# Altium schematic sheet Power_Synth.SchDoc(Power_Synth)
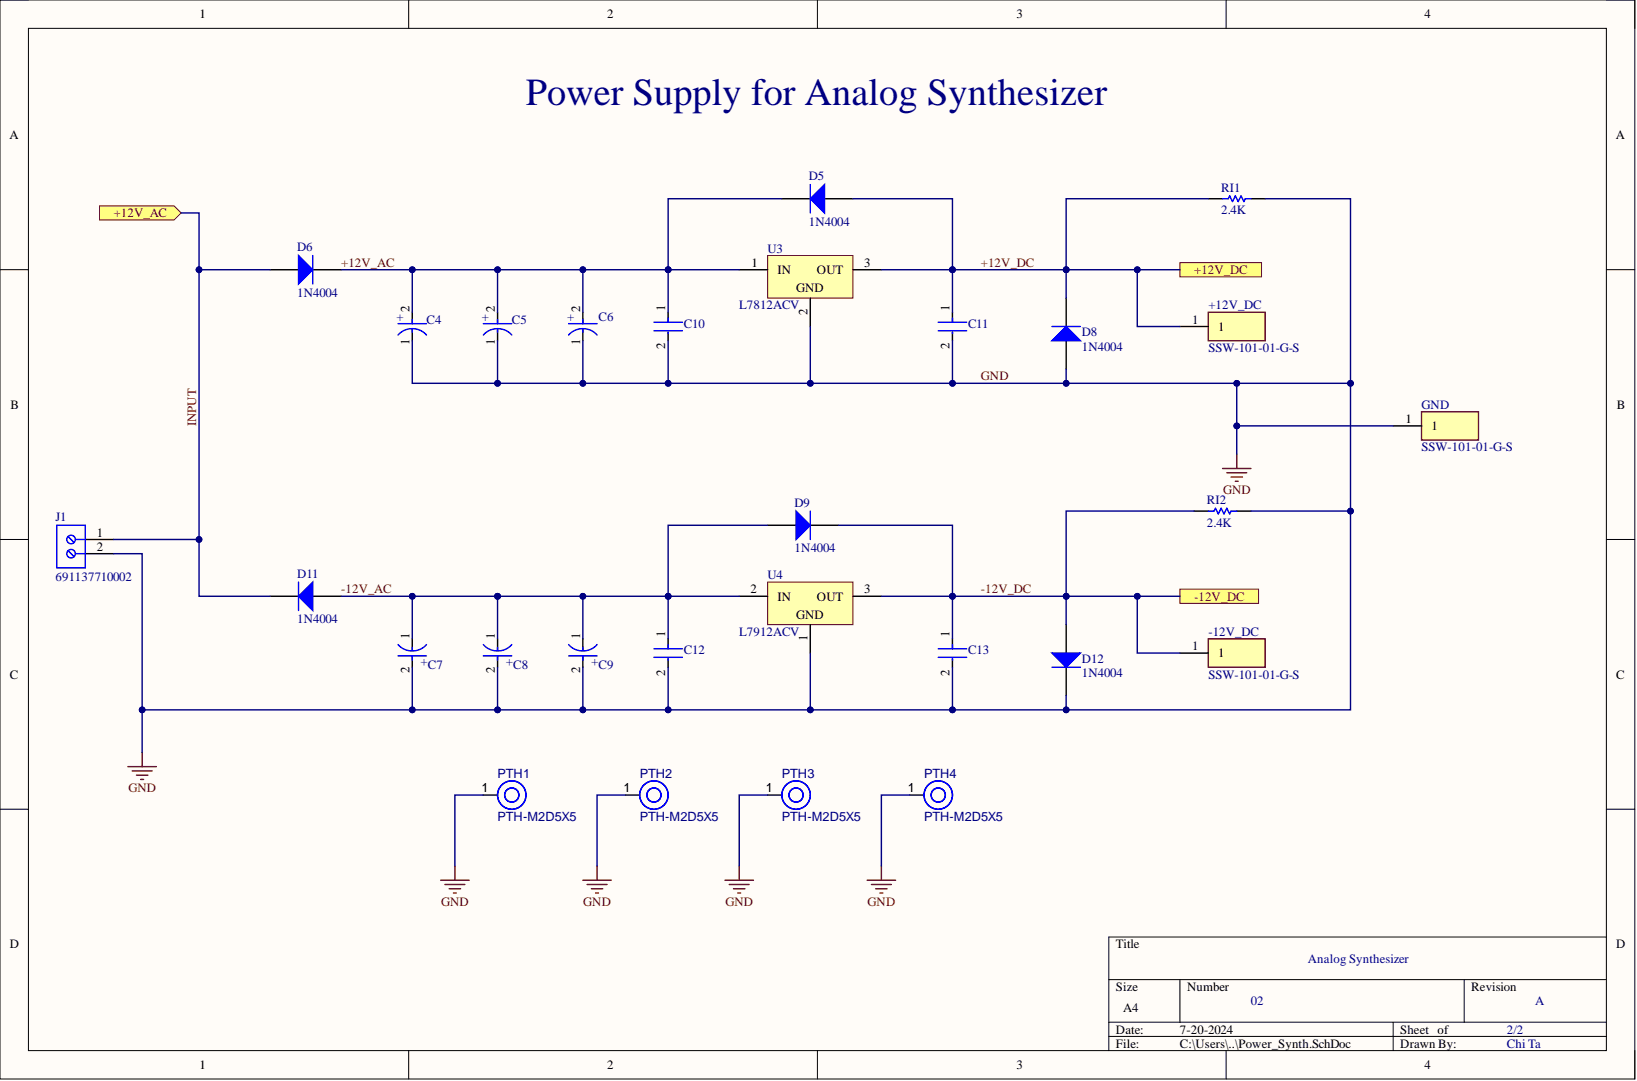
[diagram p0: Power_Synth.SchDoc]

## Parts
+12V_DC  SSW-101-01-G-S  [SSW-101-XX-YYY-S]
-12V_DC  SSW-101-01-G-S  [SSW-101-XX-YYY-S]
C4  3300uF  [FP-RAD-TH-D_18_0_5-L_35_5-MFG]  (value not drawn)
C5  3300uF  [FP-RAD-TH-D_18_0_5-L_35_5-MFG]  (value not drawn)
C6  3300uF  [FP-RAD-TH-D_18_0_5-L_35_5-MFG]  (value not drawn)
C7  3300uF  [FP-RAD-TH-D_18_0_5-L_35_5-MFG]  (value not drawn)
C8  3300uF  [FP-RAD-TH-D_18_0_5-L_35_5-MFG]  (value not drawn)
C9  3300uF  [FP-RAD-TH-D_18_0_5-L_35_5-MFG]  (value not drawn)
C10  1uF  [FP-R82DC4100DQ60J-MFG]  (value not drawn)
C11  1uF  [FP-R82DC4100DQ60J-MFG]  (value not drawn)
C12  1uF  [FP-R82DC4100DQ60J-MFG]  (value not drawn)
C13  1uF  [FP-R82DC4100DQ60J-MFG]  (value not drawn)
D5  1N4004  [FAIR-DO-41-2]
D6  1N4004  [FAIR-DO-41-2]
D8  1N4004  [FAIR-DO-41-2]
D9  1N4004  [FAIR-DO-41-2]
D11  1N4004  [FAIR-DO-41-2]
D12  1N4004  [FAIR-DO-41-2]
GND  SSW-101-01-G-S  [SSW-101-XX-YYY-S]
J1  691137710002  [691137710002]
PTH1  PTH-M2D5X5  [PTH-M2D5X5]
PTH2  PTH-M2D5X5  [PTH-M2D5X5]
PTH3  PTH-M2D5X5  [PTH-M2D5X5]
PTH4  PTH-M2D5X5  [PTH-M2D5X5]
RI1  2.4K  [RESA60-630X240]
RI2  2.4K  [RESA60-630X240]
U3  L7812ACV  [TO220]
U4  L7912ACV  [TO220]

## Ports
+12V_AC, +12V_DC, -12V_DC

## Nets
+12V_AC: C4.2 C5.2 C6.2 C10.1 D5.2 D6.2 U3.1
+12V_DC: +12V_DC.1 C11.1 D5.1 D8.2 RI1.2 U3.3 ; port +12V_DC
-12V_AC: C7.1 C8.1 C9.1 C12.1 D9.1 D11.1 U4.2
-12V_DC: -12V_DC.1 C13.1 D9.2 D12.1 RI2.2 U4.3 ; port -12V_DC
GND: C4.1 C5.1 C6.1 C7.2 C8.2 C9.2 C10.2 C11.2 C12.2 C13.2 D8.1 D12.2 GND.1 J1.2 PTH1.1 PTH2.1 PTH3.1 PTH4.1 RI1.1 RI2.1 U3.2 U4.1
INPUT: D6.1 D11.2 J1.1 ; port +12V_AC

## Library data of the parts
+12V_DC -12V_DC GND = SSW-101-01-G-S: Connector; Manufacturer_Name: SAMTEC; Arrow Part Number: SSW-101-01-G-S; Arrow Price/Stock: https://www.arrow.com/en/products/ssw-101-01-g-s/samtec; Component Kind: Standard; Datasheet Link: http://suddendocs.samtec.com/prints/ssw-1xx-xx-xxx-x-xx-xxx-xx-mkt.pdf; Height: 8.51mm; Manufacturer_Part_Number: SSW-101-01-G-S; Mouser Part Number: 200-SSW10101GS; Mouser Price/Stock: https://www.mouser.co.uk/ProductDetail/Samtec/SSW-101-01-G-S?qs=rU5fayqh%252BE20ZXdRgwMbIg%3D%3D
C4-C9 = CMP-05427-000162-1: Aluminum Electrolytic Capacitors (Radial Lead Type) AEC-Q200 Qualified 3300uF ±20% 35V; Capacitance: 3.3mF; ESR (Equivalent Series Resistance): 15mR; Lead Diameter: 0.8mm; Leakage Current: 3uA; Life (Hours): 5000Hour; Ripple Current: 3.68A; Case/Package: Radial; Component Kind: Standard; Construction: RADIAL; Contact Plating: Tin; Diameter: 18mm; Features: General Purpose; Height: 35.5mm; Height - Seated (Max): 1.398inch; Impedance: 15mR; Lead Free: Lead Free; Lead Pitch: 7.5mm; Length: 35mm; Library Name: Altium Content Vault; Max Operating Temperature: 105°C; Min Operating Temperature: -55°C; Mount: Through Hole; Number of Pins: 2; Package Quantity: 50; Packaging: Bulk; Radiation Hardening: No; REACH SVHC: No SVHC; RoHS Compliant: Yes; Series: FC; Termination: Radial; Tolerance: 20%; Voltage: 35V; Voltage Rating: 35V; Voltage Rating (DC): 35V
C10-C13 = CMP-03022-000017-1: CAP FILM 1UF 5% 63VDC RADIAL; Dielectric: PET; Dissipation Factor: 80%; Insulation Resistance: 30R; Lead Diameter: 0.5mm; Life (Hours): 2000Hour; Type: General Purpose; Voltage: 63V; Voltage Rating (AC): 40V; Capacitance: 1uF; Case/Package: Radial; Component Kind: Standard; Construction: RADIAL; Contact Plating: Tin; Depth: 5mm; Dielectric Material: PET; Height - Seated (Max): 0.398inch; Lead Pitch: 5mm; Length: 7.2mm; Library Name: Altium Content Vault; Max Operating Temperature: 105°C; Min Operating Temperature: -55°C; Mount: Through Hole; Package Quantity: 1700; Packaging: Tape and Reel; Radiation Hardening: No; REACH SVHC: No SVHC; RoHS Compliant: Yes; Series: R82; Termination: Radial; Tolerance: 5%; Voltage Rating: 63V; Voltage Rating (DC): 63V; Width: 5mm
D5 D6 D8 D9 D11 D12 = CMP-004-00004-2: General Purpose Rectifier, 400 V, 1 A, -55 to 175 degC, 2-Pin DO-204AL (DO-41), RoHS, Tape and Reel; Manufacturer: Fairchild Semiconductor; Forward Current: 1A; Forward Voltage: 1.1V; Max Surge Current: 30A; Package Version: Rev. 2, 09/2008; Peak Reverse Current: 50A; Case/Package: DO-41-2; Component Kind: Standard; Datasheet URL: https://www.fairchildsemi.com/datasheets/1N/1N4004.pdf; Datasheet Version: Rev. 1.1.0, 11/2014; Diameter: 2.72mm; Manufacturer URL: https://www.fairchildsemi.com/; Max Operating Temperature: 175°C; Min Operating Temperature: -55°C; Mounting Technology: TH; Package Description: 2-Pin Axial Lead, Dia 2.375 mm, Body Length 4.63 mm; Package Quantity: 5000; Package Reference: DO-41-2; Package URL: http://www.fairchildsemi.com/dwg/DO/DO41A.pdf; Packaging: TapeandReel; Pins: 2; RoHS Compliant: True; Library Name: TMU
J1 = CMP-1502-03324-2: NexarPartId: 78871135; Current Rating: 16A; Number of Contacts: 2; Voltage Rating: 300V; Component Kind: Standard; Contact Material: Nickel, Tin; Height: 11.6mm; Lead Pitch: 5mm; Library Name: Altium Content Vault; Max Operating Temperature: 120°C; Min Operating Temperature: -30°C; Number of Pins: 2; Number of Rows: 1; Packaging: Box; RoHS: Non-Compliant
PTH1-PTH4 = CMP-00028-00004-2: M2 PTH (2.5mm), 5mm pad; Component Kind: Standard; Pins: 1; Library Name: TMU
RI1 RI2 = CMP-1659-00074-2: Axial Resistor, 2.2 KOhm, +/- 1%, 0.25 W, -55 to 155 degC, 2-Pin THD, RoHS, Bulk; Manufacturer: Yageo; Max Power Dissipation: 250mW; Resistance: 10KOhm; Temperature Coefficient: 100ppm\/degreesC; Voltage Rating: 250V; Voltage Rating (DC): 200V; Case\/Package: Axial; Component Kind: Standard; Composition: MetalFilm; Datasheet URL: http://www.yageo.com/documents/recent/Yageo%20LR_MFR_2013.pdf; Datasheet Version: 04/2013; Lead Free: LeadFree; Length: 0.248inch; Library Name: Altium Content Vault; Manufacturer URL: http://www.yageo.com/; Max Operating Temperature: 155degC; Military Standard: Not; Min Operating Temperature: -55degC; Mount: ThroughHole; Mounting Technology: ThroughHole; Number of Terminations: 2; Package Description: 2-Pin Axial Lead, Dia 2.4 mm, Body Length 6.3 mm; Package Quantity: 200; Package Reference: MFR-25; Packaging: Bulk; Power Rating: 250mW; RoHSCompliant: True; Termination: Axial; Tolerance: 1%
U3 = CMP-0244-00451-1: Positive Voltage Regulator, 12V, 3-Pin TO-220; Component Kind: Standard; ComponentLink1Description: Manufacturer URL; ComponentLink1URL: http://www.st.com; ComponentLink2Description: Datasheet; ComponentLink2URL: http://www.st.com/internet/com/TECHNICAL_RESOURCES/TECHNICAL_LITERATURE/DATASHEET/CD00000444.pdf; ComponentLink3Description: Package Specification; ComponentLink3URL: http://www.st.com/internet/com/TECHNICAL_RESOURCES/TECHNICAL_LITERATURE/PACKAGE_INFORMATION/CD00004649.pdf; DatasheetVersion: 16/11/2011; Library Name: Altium Content Vault; PackageDescription: TO, Thru-Hole, Vertical, Heatsink Mounted; 3 In-Line Leads; Pitch 2.54 mm; PackageReference: TO220; PackageVersion: ver3.0
U4 = CMP-0244-00427-1: 2% Negative Voltage Regulator, -12V, 3-Pin TO-220; Component Kind: Standard; ComponentLink1Description: Manufacturer URL; ComponentLink1URL: http://www.st.com; ComponentLink2Description: Datasheet; ComponentLink2URL: http://www.st.com/internet/com/TECHNICAL_RESOURCES/TECHNICAL_LITERATURE/DATASHEET/CD00000451.pdf; ComponentLink3Description: Package Specification; ComponentLink3URL: http://www.st.com/internet/com/TECHNICAL_RESOURCES/TECHNICAL_LITERATURE/PACKAGE_INFORMATION/CD00004649.pdf; DatasheetVersion: 1/11/2010; Library Name: Altium Content Vault; PackageDescription: TO, Thru-Hole, Vertical, Heatsink Mounted; 3 In-Line Leads; Pitch 2.54 mm; PackageReference: TO220; PackageVersion: ver3.0
